# Altium schematic sheet Power.SchDoc
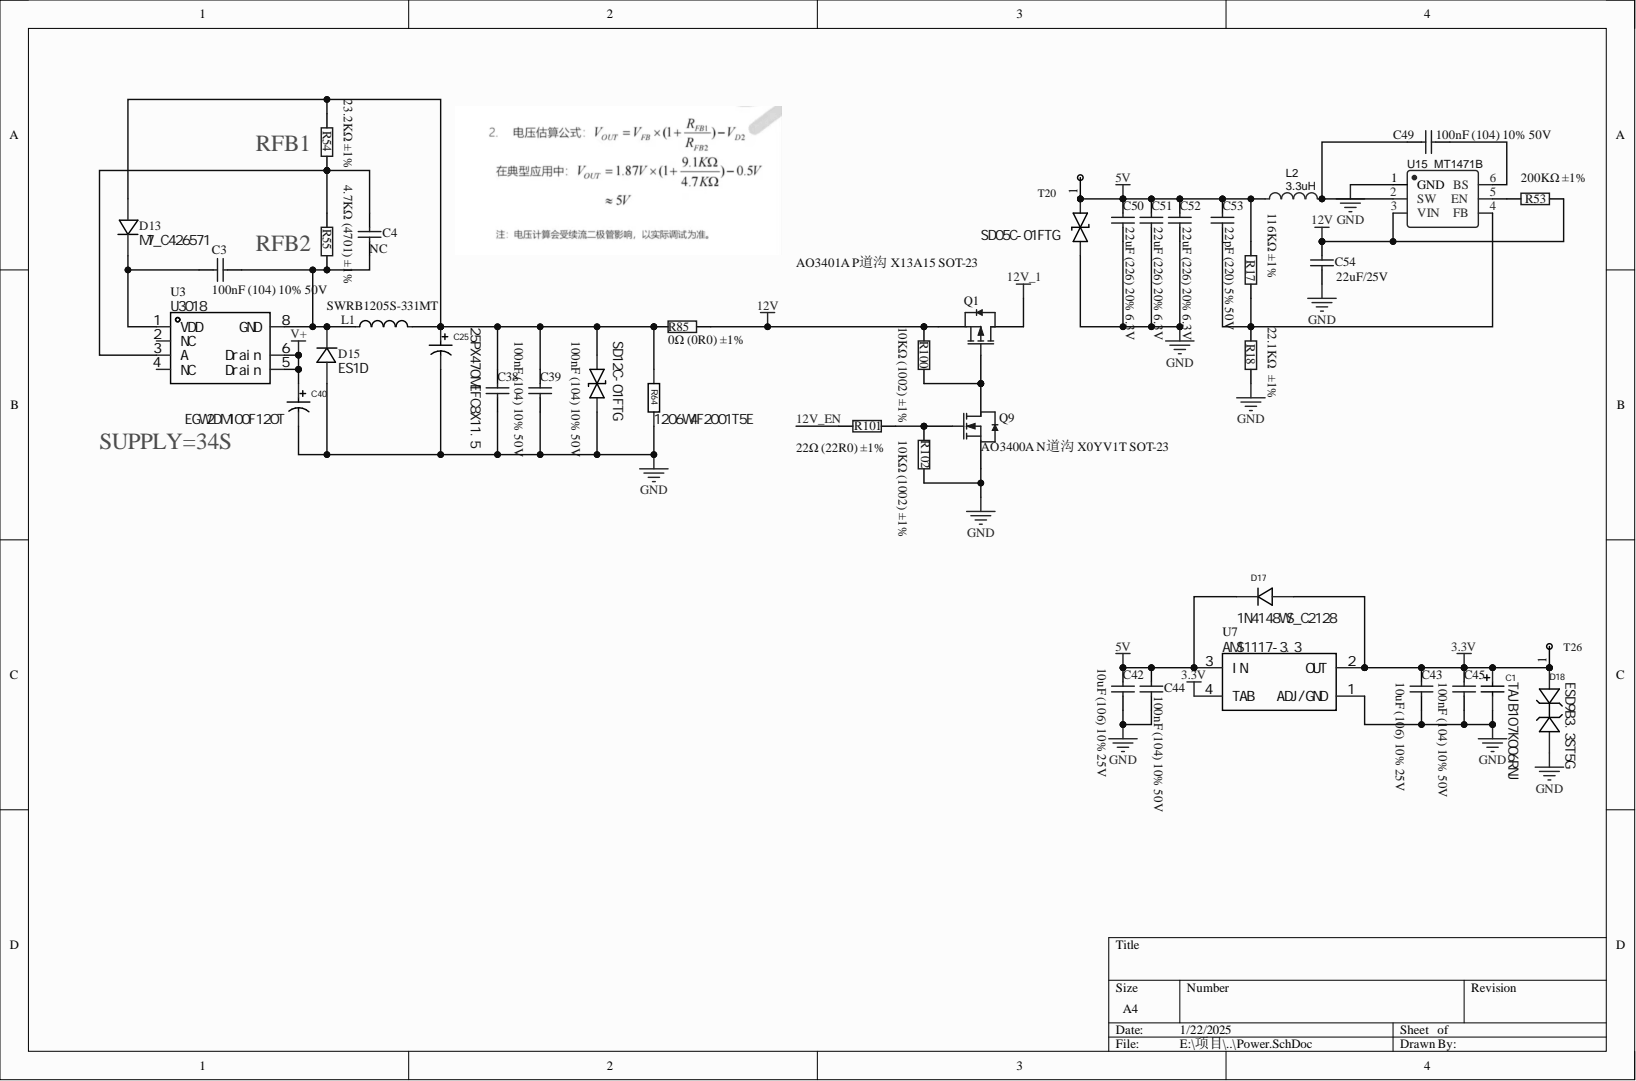
[diagram p0: Power.SchDoc]

## Parts
C1  TAJB107K006RNJ  [CASE-B_3528]
C3  100nF (104) 10% 50V  [0603_C]
C4  NC  [0603_C]
C25  25PX470MEFC8X11.5  [CAP-TH_BD8.0-P3.50-D0.6-FD]
C38  100nF (104) 10% 50V  [0603_C]
C39  100nF (104) 10% 50V  [0603_C]
C40  EGW2DM100F12OT  [CAP-TH_BD8.0-P3.50-D0.6-FD]
C42  10uF (106) 10% 25V  [0603_C]
C43  10uF (106) 10% 25V  [0603_C]
C44  100nF (104) 10% 50V  [0603_C]
C45  100nF (104) 10% 50V  [0603_C]
C49  100nF (104) 10% 50V  [0603_C]
C50  22uF (226) 20% 6.3V  [0603_C]
C51  22uF (226) 20% 6.3V  [0603_C]
C52  22uF (226) 20% 6.3V  [0603_C]
C53  22pF (220) 5% 50V  [0603_C]
C54  22uF/25V  [0805_C]
D13  M7_C426571  [SMA_L4.4-W2.6-LS5.0-RD]
D14  SD05C-01FTG  [SOD-323_L1.8-W1.3-LS2.5-BI]
D15  ES1D  [SMA_L4.4-W2.6-LS5.0-RD]
D16  SD12C-01FTG  [SOD-323_L1.8-W1.3-LS2.5-BI]
D17  1N4148WS_C2128  [SOD-323_L1.8-W1.3-LS2.5-RD]
D18  ESD9B3.3ST5G  [SOD-923_L0.8-W0.6-LS1.0-BI-1]
L1  SWRB1205S-331MT  [IND-SMD_L12.5-W12.5]
L2  3.3uH  [IND-SMD_L4.0-W4.0_SMNR4020]
Q1  AO3401A P道沟 X13A15 SOT-23  [SOT-23-3L]
Q9  AO3400A N道沟 X0YV1T SOT-23  [SOT-23-3L]
R17  116KΩ ±1%  [0603_R]
R18  22.1KΩ  ±1%  [0603_R]
R53  200KΩ ±1%  [0603_R]
R54  23.2KΩ ±1%  [0603_R]
R55  4.7KΩ (4701) ±1%  [0603_R]
R64  1206W4F2001T5E  [1206_R]
R85  0Ω (0R0) ±1%  [1206_R]
R100  10KΩ (1002) ±1%  [0603_R]
R101  22Ω (22R0) ±1%  [0603_R]
R102  10KΩ (1002) ±1%  [0603_R]
T20  Test Point  [TESTPOINT_1MM - small]  (value not drawn)
T26  Test Point  [TESTPOINT_1MM - small]  (value not drawn)
U3  U3018  [SOP-7_L4.9-W3.9-P1.27-LS6.0-BL]
U7  AMS1117-3.3  Output Voltage: 3.3V  [SOT-223-3_L6.5-W3.4-P2.30-LS7.0-BR]
U15  MT1471B  [SOT-23-6_L2.9-W1.6-P0.95-LS2.8-BR]

## Nets
3.3V: C1.1 C43.2 C45.2 D17.2 D18.2 T26.1 U7.2(OUT) U7.4(TAB)
5V: C42.2 C44.2 C50.2 C51.2 C52.2 C53.2 D14.1 D17.1 L2.1 R17.2 T20.1 U7.3(IN)
12V: C54.2 Q1.2 R53.2 R85.2 R100.1 U15.3(VIN)
12V_1: Q1.3
GND: C1.2 C25.2 C38.1 C39.1 C40.2 C42.1 C43.1 C44.1 C45.1 C50.1 C51.1 C52.1 C54.1 D14.2 D15.2 D16.2 D18.1 Q9.2 R18.1 R64.1 R102.2 U7.1(ADJ/GND) U15.1(GND)
V+: C40.1 U3.5(Drain) U3.6(Drain)
12V_EN: R101.1
NetC3_1: C3.1 C4.2 D15.1 L1.1 R55.2 U3.8(GND)
NetC3_2: C3.2 D13.1 U3.1(VDD)
NetC4_1: C4.1 R54.1 R55.1 U3.3(A)
NetC25_1: C25.1 C38.2 C39.2 D13.2 D16.1 L1.2 R54.2 R64.2 R85.1
NetC49_1: C49.1 U15.6(BS)
NetC49_2: C49.2 L2.2 U15.2(SW)
NetC53_1: C53.1 R17.1 R18.2 U15.4(FB)
NetQ1_1: Q1.1 Q9.3 R100.2
NetQ9_1: Q9.1 R101.2 R102.1
NetR53_1: R53.1 U15.5(EN)

Not connected: U3 2(NC) 4(NC)

## Library data of the parts
C1 = TAJB107K006RNJ: Manufacturer: Kyocera AVX; Add into BOM: yes; Equivalent Series Resistance(ESR): 1.7Ω@100kHz; Rated Voltage: 6.3V; Tolerance: ±10%; Value: 100uF; Component Kind: Standard; Convert to PCB: yes; Datasheet: https://atta.szlcsc.com/upload/public/pdf/source/20160107/1457707750344.pdf; Device: TAJB107K006RNJ; JLCPCB Part Class: Basic Part; LCSC Part Name: 100uF ±10% 6.3V; Manufacturer Part: TAJB107K006RNJ; Operating Temperature: -55℃~+125℃; Supplier: LCSC; Supplier Footprint: CASE-B-3528; Supplier Part: C16133; Symbol: TAJB107K006RNJ
C3 C4 C38 C39 C44 C45 C49 = 0603 100nF (104) 10% 50V: 贴片电容; Component Kind: Standard; ComponentLink1Description: 供应商链接; ComponentLink1URL: http://www.szlcsc.com/product/details_15331.html; Library Name: Cap.SchLib; Package: 0603; Supplier: LC; SuppliersPartNumber: C14663
C25 = 25PX470MEFC8X11.5: Manufacturer: rubycon(红宝石); Add into BOM: yes; L: 11.5mm; Lifetime @ Temp: 1000hrs@105℃; Name: 470uF; Rated Voltage: 25V; Ripple Current: 440mA@120Hz; Tolerance: ±20%; Value: 470uF; φD: 8mm; Component Kind: Standard; Convert to PCB: yes; Datasheet: https://atta.szlcsc.com/upload/public/pdf/source/20171026/C136025_15089841766351059112.pdf; Device: 25PX470MEFC8X11.5; Equivalent Series Resistance(ESR): -; JLCPCB Part Class: Extended Part; LCSC Part Name: 470uF ±20% 25V; Lead Spacing: 3.5mm; Manufacturer Part: 25PX470MEFC8X11.5; Operating Temperature: -25℃~+105℃; PCB3D: CAP-TH_D8.0-H8.0-P3.50; Supplier: LCSC; Supplier Footprint: 插件,D8xL11.5mm; Supplier Part: C88730; Symbol: 25PX470MEFC8X11.5
C40 = EGW2DM100F12OT: Manufacturer: AISHI(艾华集团); Add into BOM: yes; L: 12mm; Lifetime @ Temp: 10000hrs@105℃; Name: 10uF; Rated Voltage: 200V; Tolerance: ±20%; Value: 10uF; φD: 8mm; Component Kind: Standard; Convert to PCB: yes; Datasheet: https://atta.szlcsc.com/upload/public/pdf/source/20190702/C401582_D3BC84659605B9063033D8EAF91450C1.pdf; Device: EGW2DM100F12OT; JLCPCB Part Class: Extended Part; LCSC Part Name: 10uF ±20% 200V; Lead Spacing: 3.5mm; Manufacturer Part: EGW2DM100F12OT; PCB3D: CAP-TH_D8.0-H8.0-P3.50; Supplier: LCSC; Supplier Footprint: 插件,D8xL12mm; Supplier Part: C112474; Symbol: EGW2DM100F12OT
C42 C43 = 0805 10uF (106) 10% 25V: 贴片电容; Component Kind: Standard; ComponentLink1Description: 供应商链接; ComponentLink1URL: http://www.szlcsc.com/product/details_16532.html; Library Name: Cap.SchLib; Package: 0805; Supplier: LC; SuppliersPartNumber: C15850
C50-C52 = 0603 22uF (226) 20% 6.3V: 贴片电容; Component Kind: Standard; ComponentLink1Description: 供应商链接; ComponentLink1URL: http://www.szlcsc.com/product/details_60514.html; Library Name: Miscellaneous Devices LC.IntLib; Package: 0603; Supplier: LC; SuppliersPartNumber: C59461
C53 = 0402 22pF (220) 5% 50V: 贴片电容; Component Kind: Standard; ComponentLink1Description: 供应商链接; ComponentLink1URL: http://www.szlcsc.com/product/details_1907.html; Library Name: Miscellaneous Devices LC.IntLib; Package: 0402; Supplier: LC; SuppliersPartNumber: C1555
C54 = 0805 22uF (226) 20% 25V: 贴片电容; Component Kind: Standard; ComponentLink1Description: 供应商链接; ComponentLink1URL: http://www.szlcsc.com/product/details_46786.html; Library Name: Miscellaneous Devices LC.IntLib; Package: 0805; Supplier: LC; SuppliersPartNumber: C45783
D13 D15 = M7_C426571: Manufacturer: Slkor(萨科微); Manufacturer Part: M7; Add into BOM: yes; Average Rectified Current (Io): 1A; Forward Voltage (Vf@If): 1.1V@1A; Reverse Leakage Current: 5uA@1kV; Reverse Voltage (Vr): 1kV; Component Kind: Standard; Convert to PCB: yes; Datasheet: https://atta.szlcsc.com/upload/public/pdf/source/20190906/C426571_1E602879C535CAAD5C0A1EB673ADB91C.pdf; Device: M7_C426571; Diode Configuration: -; JLCPCB Part Class: Extended Part; LCSC Part Name: 电压:1kV 电流:1A; Name: M7; PCB3D: SMA_L4.4-W2.6-LS5.0-RD; Supplier: LCSC; Supplier Footprint: SMA(DO-214AC); Supplier Part: C426571; Symbol: M7_C426571
D14 D16 = SD12C-01FTG: Manufacturer: Littelfuse(美国力特); Add into BOM: yes; Bidirectional Channels: 1; Maximum Clamping Voltage: 23V; Peak Pulse Current (Ipp)@10/1000us: 17A@8/20us; Peak Pulse Power Dissipation (Ppp)@10/1000us: 450W; Reverse Stand-Off Voltage (Vrwm): 12V@Max; Component Kind: Standard; Convert to PCB: yes; Datasheet: https://atta.szlcsc.com/upload/public/pdf/source/20180622/C207246_8460F79C10502DD599B6980D9B202D70.pdf; Device: SD12C-01FTG; JLCPCB Part Class: Extended Part; LCSC Part Name: SD12C-01FTG; Manufacturer Part: SD12C-01FTG; Name: SD12C-01FTG; PCB3D: SOD-323_L1.7-W1.3-H1.1-LS2.5; Supplier: LCSC; Supplier Footprint: SOD-323; Supplier Part: C135970; Symbol: SD12C-01FTG
D17 = 1N4148WS_C2128: Manufacturer: CJ(江苏长电/长晶); Add into BOM: yes; Average Rectified Current (Io): 150mA; Diode Configuration: 独立式; Forward Voltage (Vf@If): 1.25V@150mA; Power Dissipation: 200mW; Reverse Leakage Current: 1uA@75V; Reverse Recovery Time (trr): 4ns; Reverse Voltage (Vr): 100V; Symbol: 1N4148WS T4; Component Kind: Standard; Convert to PCB: yes; Datasheet: https://atta.szlcsc.com/upload/public/pdf/source/20140809/1457707208178.pdf; Device: 1N4148WS_C2128; JLCPCB Part Class: Basic Part; LCSC Part Name: 电压:100V 电流:150mA; Manufacturer Part: 1N4148WS; Name: 1N4148WS; Operating Temperature: +150℃@(Tj); PCB3D: SOD-323_L1.7-W1.3-H1.1-LS2.5; Supplier: LCSC; Supplier Footprint: SOD-323; Supplier Part: C2128
D18 = ESD9B3.3ST5G: Manufacturer: onsemi(安森美); Add into BOM: yes; Breakdown Voltage (VBR): 5V; Clamping Voltage@Ipp: 11.5V; Junction Capacitance(Cj)@1MHz: 15pF@1MHz; Peak Pulse Current(Ipp)@8/20us: 2A; Polarity: 双向; Reverse Stand-Off Voltage (Vrwm): 3.3V; Component Kind: Standard; Convert to PCB: yes; Datasheet: https://atta.szlcsc.com/upload/public/pdf/source/20170322/1490151675931.PDF; Device: ESD9B3.3ST5G; JLCPCB Part Class: Extended Part; LCSC Part Name: ESD9B3.3ST5G; Manufacturer Part: ESD9B3.3ST5G; Name: ESD9B3.3ST5G; Operating Temperature: -55℃~+150℃@(Tj); PCB3D: SOD-923_L0.8-W0.6-LS1.0-BI-1; Peak Pulse Power(Ppp)@8/20us: -; Supplier: LCSC; Supplier Footprint: SOD-923-2; Supplier Part: C96512; Symbol: ESD9B3.3ST5G
L1 = SWRB1205S-331MT: Manufacturer: Sunlord(顺络); Add into BOM: yes; DC Resistance (DCR): 510mΩ; Name: 330uH; Rated Current: 680mA; Tolerance: ±20%; Value: 330uH; Component Kind: Standard; Convert to PCB: yes; Datasheet: https://atta.szlcsc.com/upload/public/pdf/source/20210916/823C2395B4DE7135801A13FF04A7C75C.pdf; Device: SWRB1205S-331MT; JLCPCB Part Class: Extended Part; LCSC Part Name: 330uH ±20% 680mA 510mΩ; Manufacturer Part: SWRB1205S-331MT; PCB3D: SMD-PIND_L12.5-W12.5-H8.0; Supplier: LCSC; Supplier Footprint: SMD; Supplier Part: C169392; Symbol: SWRB1205S-331MT
L2 = 10uH: BOM_Manufacturer: SXN; BOM_Manufacturer Part: SMNR4020-10UH; nameAlias: Inductance; BOM_JLCPCB Part Class: Extended Part; BOM_Supplier: LCSC; BOM_Supplier Part: C135263; Component Kind: Standard; spicePre: L; spiceSymbolName: SMNR4020-10UH
Q1 = AO3401A P道沟 X13A15 SOT-23: MOS(场效应管); Component Kind: Standard; ComponentLink1Description: 供应商链接; ComponentLink1URL: http://www.szlcsc.com/product/details_15801.html; Library Name: MOS.SchLib; Package: SOT-23; Supplier: LC; SuppliersPartNumber: C15127
Q9 = AO3400A N道沟 X0YV1T SOT-23: MOS(场效应管); Component Kind: Standard; ComponentLink1Description: 供应商链接; ComponentLink1URL: http://www.szlcsc.com/product/details_21629.html; Library Name: MOS.SchLib; Package: SOT-23; Supplier: LC; SuppliersPartNumber: C20917
R17 = 0402 100KΩ (1003) 1%: 贴片电阻; Component Kind: Standard; ComponentLink1Description: 供应商链接; ComponentLink1URL: http://www.szlcsc.com/product/details_26484.html; Library Name: Miscellaneous Devices LC.IntLib; Package: 0402; Supplier: LC; SuppliersPartNumber: C25741
R18 = 0402 18KΩ (1802) 1%: 贴片电阻; Component Kind: Standard; ComponentLink1Description: 供应商链接; ComponentLink1URL: http://www.szlcsc.com/product/details_26505.html; Library Name: Miscellaneous Devices LC.IntLib; Package: 0402; Supplier: LC; SuppliersPartNumber: C25762
R53 = 0402 10KΩ (1002) 1%: 贴片电阻; Component Kind: Standard; ComponentLink1Description: 供应商链接; ComponentLink1URL: http://www.szlcsc.com/product/details_26487.html; Library Name: Miscellaneous Devices LC.IntLib; Package: 0402; Supplier: LC; SuppliersPartNumber: C25744
R54 = 0603 24KΩ (2402) 1%: 贴片电阻; Component Kind: Standard; ComponentLink1Description: 供应商链接; ComponentLink1URL: http://www.szlcsc.com/product/details_24085.html; Library Name: RES.SchLib; Package: 0603; Supplier: LC; SuppliersPartNumber: C23352
R55 = 0603 4.7KΩ (4701) 1%: 贴片电阻; Component Kind: Standard; ComponentLink1Description: 供应商链接; ComponentLink1URL: http://www.szlcsc.com/product/details_23889.html; Library Name: RES.SchLib; Package: 0603; Supplier: LC; SuppliersPartNumber: C23162
R64 = 1206W4F2001T5E: Manufacturer: UNI-ROYAL(厚声); Add into BOM: yes; Name: 2kΩ; Overload Voltage (Max): 200V; Power(Watts): 250mW; Temperature Coefficient: ±100ppm/℃; Tolerance: ±1%; Value: 2kΩ; Component Kind: Standard; Convert to PCB: yes; Datasheet: https://atta.szlcsc.com/upload/public/pdf/source/20200306/C422600_1E6D84923E4A46A82E41ADD87F860B5C.pdf; Device: 1206W4F2001T5E; JLCPCB Part Class: Basic Part; LCSC Part Name: 2kΩ ±1% 250mW 厚膜电阻; Manufacturer Part: 1206W4F2001T5E; Operating Temperature Range: -55℃~+155℃; PCB3D: R1206_L3.2-W1.6-H0.6; Supplier: LCSC; Supplier Footprint: 1206; Supplier Part: C17944; Symbol: 1206W4F2001T5E; Type: 厚膜电阻
R85 = 1206 0Ω (0R0) 1%: 贴片电阻; Component Kind: Standard; ComponentLink1Description: 供应商链接; ComponentLink1URL: http://www.szlcsc.com/product/details_18576.html; Library Name: RES.SchLib; Package: 1206; Supplier: LC; SuppliersPartNumber: C17888
R100 R102 = 0603 10KΩ (1002) 1%: 贴片电阻; Component Kind: Standard; ComponentLink1Description: 供应商链接; ComponentLink1URL: http://www.szlcsc.com/product/details_26547.html; Library Name: Miscellaneous Devices LC.IntLib; Package: 0603; Supplier: LC; SuppliersPartNumber: C25804
R101 = 0603 22Ω (22R0) 1%: 贴片电阻; Component Kind: Standard; ComponentLink1Description: 供应商链接; ComponentLink1URL: http://www.szlcsc.com/product/details_24078.html; Library Name: RES.SchLib; Package: 0603; Supplier: LC; SuppliersPartNumber: C23345
T20 T26 = U: Component Kind: Standard
U3 = U3018: Manufacturer: UNI-SEMI(宇力半导体); Add into BOM: yes; Component Kind: Standard; Convert to PCB: yes; Datasheet: https://atta.szlcsc.com/upload/public/pdf/source/20210810/D89C1FD5B5EB934B619B89B6554D6555.pdf; Device: U3018; JLCPCB Part Class: Extended Part; LCSC Part Name: U3018; Manufacturer Part: U3018; Name: U3018; PCB3D: SOP-7_L4.9-W3.9-H1.5-LS6.0-P1.27; Supplier: LCSC; Supplier Footprint: SOP7; Supplier Part: C2874738; Symbol: U3018
U7 = LM1117S-3.3: Manufacturer: HTC; Add into BOM: yes; Dropout Voltage: 1.3V@(1A); Maximum Input Voltage: 12V; Number of Outputs: 1; Output Current: 1A; Output Polarity: 正; Output Type: 固定; Power Supply Rejection Ratio (PSRR): 75dB@(120Hz); Component Kind: Standard; Convert to PCB: yes; Datasheet: https://atta.szlcsc.com/upload/public/pdf/source/20170814/C126027_1502678373551885899.pdf; Device: LM1117S-3.3; JLCPCB Part Class: Extended Part; LCSC Part Name: 输入12V 输出3.3V 1A; Manufacturer Part: LM1117S-3.3; Name: LM1117S-3.3; PCB3D: SOT-223-4P_L6.5-W3.5-H1.6-LS7.0-P2.30; Supplier: LCSC; Supplier Footprint: SOT-223; Supplier Part: C126027; Symbol: LM1117S-3.3
U15 = MT1471: BOM_Manufacturer: 西安航天民芯; Contributor: LCSC; BOM_JLCPCB Part Class: Extended Part; BOM_Manufacturer Part: MT1471; BOM_Supplier: LCSC; BOM_Supplier Part: C2684932; Component Kind: Standard; spicePre: U; spiceSymbolName: MT1471
